# Altium schematic sheet Kobe.SchDoc
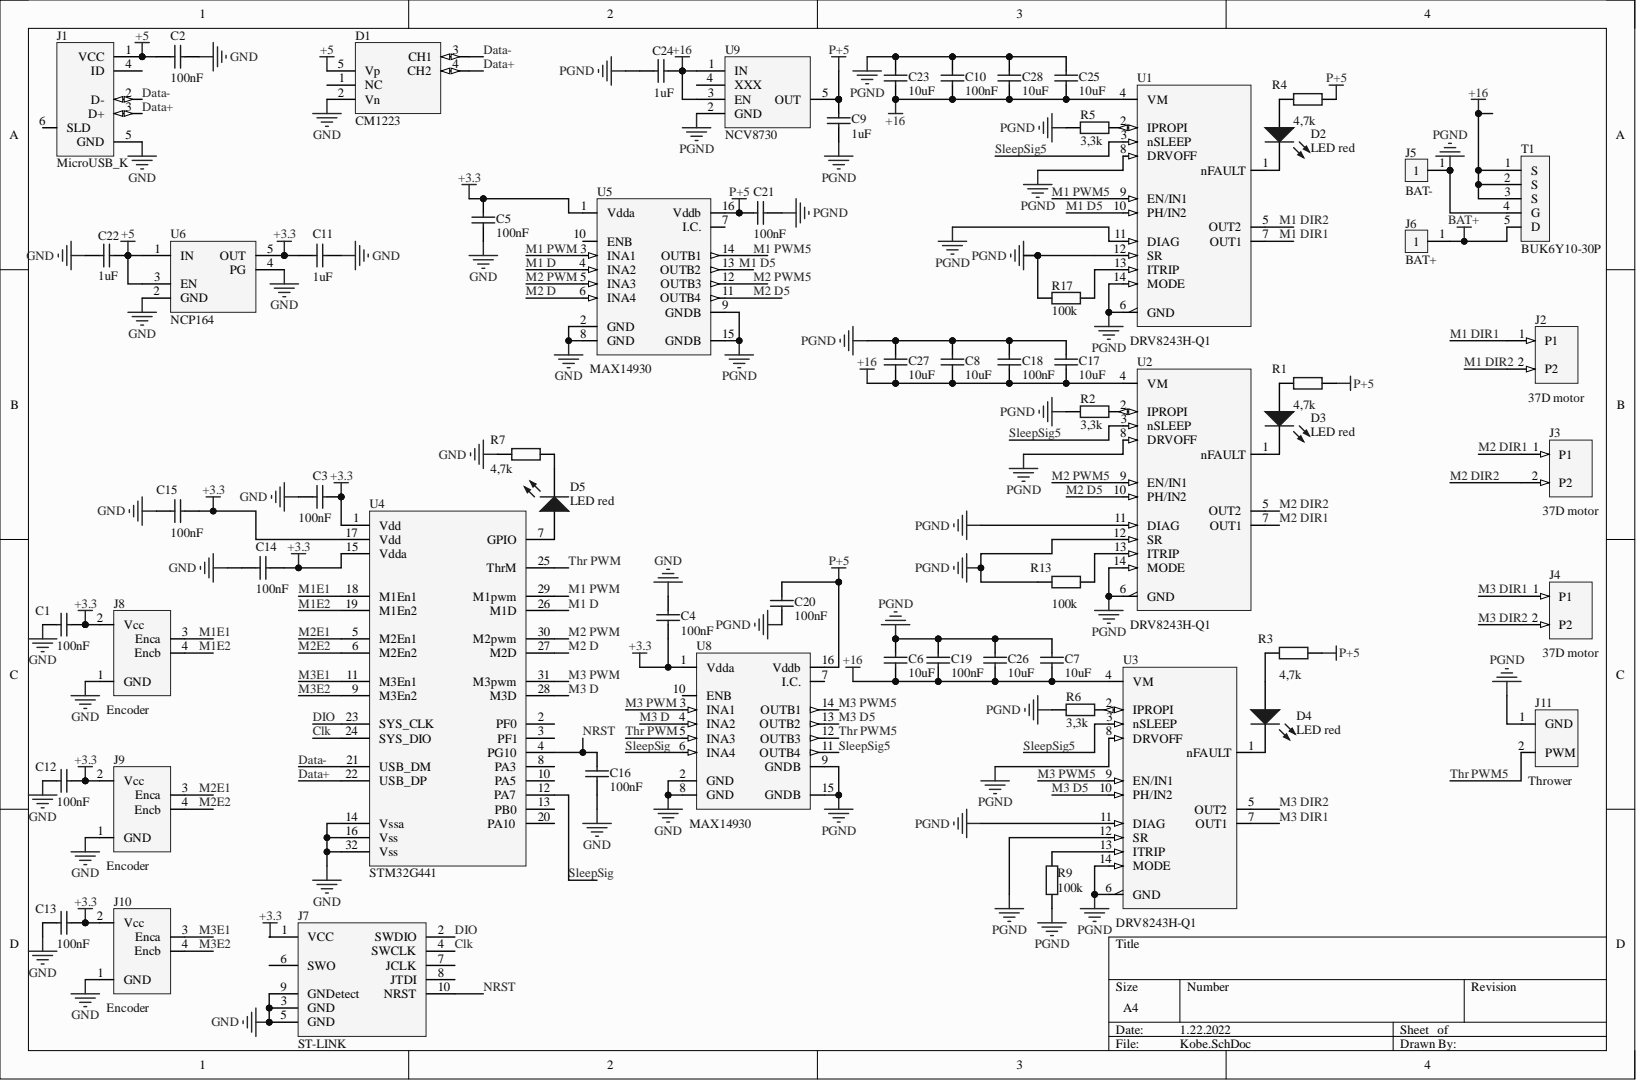
[diagram p0: Kobe.SchDoc]

## Parts
C1  100nF  [Capacitor 0603-Footprint-1]
C2  100nF  [Capacitor 0603-Footprint-1]
C3  100nF  [Capacitor 0603-Footprint-1]
C4  100nF  [Capacitor 0603-Footprint-1]
C5  100nF  [Capacitor 0603-Footprint-1]
C6  10uF  [Cap 1206-Footprint-1]
C7  10uF  [Cap 1206-Footprint-1]
C8  10uF  [Cap 1206-Footprint-1]
C9  1uF  [Capacitor 0603-Footprint-1]
C10  100nF  [Capacitor 0603-Footprint-1]
C11  1uF  [Capacitor 0603-Footprint-1]
C12  100nF  [Capacitor 0603-Footprint-1]
C13  100nF  [Capacitor 0603-Footprint-1]
C14  100nF  [Capacitor 0603-Footprint-1]
C15  100nF  [Capacitor 0603-Footprint-1]
C16  100nF  [Capacitor 0603-Footprint-1]
C17  10uF  [Cap 1206-Footprint-1]
C18  100nF  [Capacitor 0603-Footprint-1]
C19  100nF  [Capacitor 0603-Footprint-1]
C20  100nF  [Capacitor 0603-Footprint-1]
C21  100nF  [Capacitor 0603-Footprint-1]
C22  1uF  [Capacitor 0603-Footprint-1]
C23  10uF  [Cap 1206-Footprint-1]
C24  1uF  [Capacitor 0603-Footprint-1]
C25  10uF  [Cap 1206-Footprint-1]
C26  10uF  [Cap 1206-Footprint-1]
C27  10uF  [Cap 1206-Footprint-1]
C28  10uF  [Cap 1206-Footprint-1]
D1  CM1223  [CM1223-Footprint-1]
D2  LED red  [LED red-Footprint-1]
D3  LED red  [LED red-Footprint-1]
D4  LED red  [LED red-Footprint-1]
D5  LED red  [LED red-Footprint-1]
J1  MicroUSB_K  [MicroUSB Kobe-Footprint-1]
J2  37D motor  [37D motor-Footprint-1]
J3  37D motor  [37D motor-Footprint-1]
J4  37D motor  [37D motor-Footprint-1]
J5  BAT-  [BAT--Footprint-1]
J6  BAT+  [BAT+-Footprint-1]
J7  ST-LINK  [ST-LINK-Footprint-1]
J8  Encoder  [Encoder-Footprint-1]
J9  Encoder  [Encoder-Footprint-1]
J10  Encoder  [Encoder-Footprint-1]
J11  Thrower  [Thrower-Footprint-1]
R1  4,7k  [Res 0603-Footprint-1]
R2  3,3k  [Res 0603-Footprint-1]
R3  4,7k  [Res 0603-Footprint-1]
R4  4,7k  [Res 0603-Footprint-1]
R5  3,3k  [Res 0603-Footprint-1]
R6  3,3k  [Res 0603-Footprint-1]
R7  4,7k  [Res 0603-Footprint-1]
R9  100k  [Res 0603-Footprint-1]
R13  100k  [Res 0603-Footprint-1]
R17  100k  [Res 0603-Footprint-1]
T1  BUK6Y10-30P  [BUK6Y10-30P-Footprint-1]
U1  DRV8243H-Q1  [New footprint 1]
U2  DRV8243H-Q1  [New footprint 1]
U3  DRV8243H-Q1  [New footprint 1]
U4  STM32G441  [STM32G441-Footprint-1]
U5  MAX14930  [PCB-84rmxw6pcxfeioopl5s3-1]
U6  NCP164  [NCP164-Footprint-1]
U8  MAX14930  [PCB-84rmxw6pcxfeioopl5s3-1]
U9  NCV8730  [NCV8730-Footprint-1]

## Nets
+3.3: C1.2 C3.2 C4.1 C5.2 C11.1 C12.2 C13.2 C14.2 C15.2 J7.1(VCC) J8.2(Vcc) J9.2(Vcc) J10.2(Vcc) U4.1(Vdd) U4.15(Vdda) U4.17(Vdd) U5.1(Vdda) U6.5(OUT) U8.1(Vdda)
+5: C2.1 C22.1 D1.5(Vp) J1.1(VCC) U6.1(IN) U6.3(EN)
+16: C6.1 C7.1 C8.1 C10.2 C17.1 C18.2 C19.2 C23.1 C24.1 C25.1 C26.1 C27.1 C28.1 T1.1(S) T1.2(S) T1.3(S) U1.4(VM) U2.4(VM) U3.4(VM) U9.1(IN) U9.3(EN)
BAT+: J6.1 T1.5(D)
GND: C1.1 C2.2 C3.1 C4.2 C5.1 C11.2 C12.1 C13.1 C14.1 C15.1 C16.2 C22.2 D1.2(Vn) J1.5(GND) J7.3(GND) J7.5(GND) J7.9(GNDetect) J8.1(GND) J9.1(GND) J10.1(GND) R7.1 U4.14(Vssa) U4.16(Vss) U4.32(Vss) U5.2(GND) U5.8(GND) U6.2(GND) U6.4(PG) U8.2(GND) U8.8(GND)
P+5: C9.1 C20.2 C21.1 R1.2 R3.2 R4.2 U5.16(Vddb) U8.16(Vddb) U9.5(OUT)
PGND: C6.2 C7.2 C8.2 C9.2 C10.1 C17.2 C18.1 C19.1 C20.1 C21.2 C23.2 C24.2 C25.2 C26.2 C27.2 C28.2 J5.1 J11.1 R2.2 R5.2 R6.2 R9.1 R13.1 R17.2 T1.4(G) U1.6(GND) U1.8(DRVOFF) U1.11(DIAG) U1.12(SR) U1.14(MODE) U2.6(GND) U2.8(DRVOFF) U2.11(DIAG) U2.12(SR) U2.14(MODE) U3.6(GND) U3.8(DRVOFF) U3.11(DIAG) U3.12(SR) U3.14(MODE) U5.9(GNDB) U5.15(GNDB) U8.9(GNDB) U8.15(GNDB) U9.2(GND)
Clk: J7.4(SWCLK) U4.24(SYS_DIO)
Data+: D1.4(CH2) J1.3(D+) U4.22(USB_DP)
Data-: D1.3(CH1) J1.2(D-) U4.21(USB_DM)
DIO: J7.2(SWDIO) U4.23(SYS_CLK)
M1 D: U4.26(M1D) U5.4(INA2)
M1 D5: U1.10(PH/IN2) U5.13(OUTB2)
M1 DIR1: J2.1 U1.7(OUT1)
M1 DIR2: J2.2 U1.5(OUT2)
M1 PWM: U4.29(M1pwm) U5.3(INA1)
M1 PWM5: U1.9(EN/IN1) U5.14(OUTB1)
M1E1: J8.3(Enca) U4.18(M1En1)
M1E2: J8.4(Encb) U4.19(M1En2)
M2 D: U4.27(M2D) U5.6(INA4)
M2 D5: U2.10(PH/IN2) U5.11(OUTB4)
M2 DIR1: J3.1 U2.7(OUT1)
M2 DIR2: J3.2 U2.5(OUT2)
M2 PWM: U4.30(M2pwm) U5.5(INA3)
M2 PWM5: U2.9(EN/IN1) U5.12(OUTB3)
M2E1: J9.3(Enca) U4.5(M2En1)
M2E2: J9.4(Encb) U4.6(M2En2)
M3 D: U4.28(M3D) U8.4(INA2)
M3 D5: U3.10(PH/IN2) U8.13(OUTB2)
M3 DIR1: J4.1 U3.7(OUT1)
M3 DIR2: J4.2 U3.5(OUT2)
M3 PWM: U4.31(M3pwm) U8.3(INA1)
M3 PWM5: U3.9(EN/IN1) U8.14(OUTB1)
M3E1: J10.3(Enca) U4.11(M3En1)
M3E2: J10.4(Encb) U4.9(M3En2)
NRST: C16.1 J7.10(NRST) U4.4(PG10)
SleepSig: U4.12(PA7) U8.6(INA4)
SleepSig5: U1.3(nSLEEP) U2.3(nSLEEP) U3.3(nSLEEP) U8.11(OUTB4)
Thr PWM: U4.25(ThrM) U8.5(INA3)
Thr PWM5: J11.2 U8.12(OUTB3)
NetD2_1: D2.1 R4.1
NetD2_2: D2.2 U1.1(nFAULT)
NetD3_1: D3.1 R1.1
NetD3_2: D3.2 U2.1(nFAULT)
NetD4_1: D4.1 R3.1
NetD4_2: D4.2 U3.1(nFAULT)
NetD5_1: D5.1 U4.7(GPIO)
NetD5_2: D5.2 R7.2
NetR2_1: R2.1 U2.2(IPROPI)
NetR5_1: R5.1 U1.2(IPROPI)
NetR6_1: R6.1 U3.2(IPROPI)
NetR9_2: R9.2 U3.13(ITRIP)
NetR13_2: R13.2 U2.13(ITRIP)
NetR17_1: R17.1 U1.13(ITRIP)

Not connected: D1 1(NC); J1 4(ID) 6(SLD); J7 6(SWO) 7(JCLK) 8(JTDI); U4 2(PF0) 3(PF1) 8(PA3) 10(PA5) 13(PB0) 20(PA10); U5 7(I.C.) 10(ENB); U8 7(I.C.) 10(ENB); U9 4(XXX)

## Library data of the parts
C1 = CMP-g4figae3br6edw50sj97-2: Kondekas toite jaoks; ReplaceComponentId: QXJNIHPQ; Component Kind: Standard; CustomComponentID: g4figae3br6edw50sj97; Library Name: My Personal Space; PartId: 1
C2 = CMP-g4figae3br6edw50sj97-2: Kondekas toite jaoks; ReplaceComponentId: LWVMDZGM; Component Kind: Standard; CustomComponentID: g4figae3br6edw50sj97; Library Name: My Personal Space; PartId: 1
C3 = CMP-g4figae3br6edw50sj97-2: Kondekas toite jaoks; ReplaceComponentId: HDSDZMXK; Component Kind: Standard; CustomComponentID: g4figae3br6edw50sj97; Library Name: My Personal Space; PartId: 1
C4 = CMP-g4figae3br6edw50sj97-2: Kondekas toite jaoks; ReplaceComponentId: BXIVBJPT; Component Kind: Standard; CustomComponentID: g4figae3br6edw50sj97; Library Name: My Personal Space; PartId: 1
C5 = CMP-g4figae3br6edw50sj97-2: Kondekas toite jaoks; ReplaceComponentId: SYWEGAUD; Component Kind: Standard; CustomComponentID: g4figae3br6edw50sj97; Library Name: My Personal Space; PartId: 1
C6-C8 C17 C23 C25-C28 = CMP-nz8wtry6sz9ghr0bdznt-1: Capacitor 1206; Component Kind: Standard; CustomComponentID: nz8wtry6sz9ghr0bdznt; Library Name: My Personal Space
C9 = CMP-g4figae3br6edw50sj97-2: Kondekas toite jaoks; ReplaceComponentId: UPAHNOOW; Component Kind: Standard; CustomComponentID: g4figae3br6edw50sj97; Library Name: My Personal Space; PartId: 1
C10 C18 C19 = CMP-g4figae3br6edw50sj97-2: Kondekas toite jaoks; ReplaceComponentId: RNKTVTKK; Component Kind: Standard; CustomComponentID: g4figae3br6edw50sj97; Library Name: My Personal Space; PartId: 1
C11 C22 = CMP-g4figae3br6edw50sj97-2: Kondekas toite jaoks; ReplaceComponentId: WRXFJEIN; Component Kind: Standard; CustomComponentID: g4figae3br6edw50sj97; Library Name: My Personal Space; PartId: 1
C12 = CMP-g4figae3br6edw50sj97-2: Kondekas toite jaoks; ReplaceComponentId: ARRJWFSM; Component Kind: Standard; CustomComponentID: g4figae3br6edw50sj97; Library Name: My Personal Space; PartId: 1
C13 = CMP-g4figae3br6edw50sj97-2: Kondekas toite jaoks; ReplaceComponentId: YRGIVPHM; Component Kind: Standard; CustomComponentID: g4figae3br6edw50sj97; Library Name: My Personal Space; PartId: 1
C14 = CMP-g4figae3br6edw50sj97-2: Kondekas toite jaoks; ReplaceComponentId: YVIIQBOT; Component Kind: Standard; CustomComponentID: g4figae3br6edw50sj97; Library Name: My Personal Space; PartId: 1
C15 = CMP-g4figae3br6edw50sj97-2: Kondekas toite jaoks; ReplaceComponentId: WGZMWOWH; Component Kind: Standard; CustomComponentID: g4figae3br6edw50sj97; Library Name: My Personal Space; PartId: 1
C16 = CMP-g4figae3br6edw50sj97-2: Kondekas toite jaoks; ReplaceComponentId: PQVYLEFT; Component Kind: Standard; CustomComponentID: g4figae3br6edw50sj97; Library Name: My Personal Space; PartId: 1
C20 = CMP-g4figae3br6edw50sj97-2: Kondekas toite jaoks; ReplaceComponentId: VKLBJWWP; Component Kind: Standard; CustomComponentID: g4figae3br6edw50sj97; Library Name: My Personal Space; PartId: 1
C21 = CMP-g4figae3br6edw50sj97-2: Kondekas toite jaoks; ReplaceComponentId: SXEWBLQL; Component Kind: Standard; CustomComponentID: g4figae3br6edw50sj97; Library Name: My Personal Space; PartId: 1
C24 = CMP-g4figae3br6edw50sj97-2: Kondekas toite jaoks; ReplaceComponentId: OFTCDOFN; Component Kind: Standard; CustomComponentID: g4figae3br6edw50sj97; Library Name: My Personal Space; PartId: 1
D1 = CMP-2zhtxkk7tc9sql6uishn-7: TVS diode, USB; ReplaceComponentId: UULFGQCP; Component Kind: Standard; CustomComponentID: 2zhtxkk7tc9sql6uishn; Library Name: My Personal Space; PartId: 1
D2 = CMP-r4czjv7pygs5mjbj75ts-2: Punane led. Driveri nFaault. 2V pingelandg; ReplaceComponentId: YTTIPKNN; Component Kind: Standard; CustomComponentID: r4czjv7pygs5mjbj75ts; Library Name: My Personal Space; PartId: 1
D3 = CMP-r4czjv7pygs5mjbj75ts-2: Punane led. Driveri nFaault. 2V pingelandg; ReplaceComponentId: JXVFREHO; Component Kind: Standard; CustomComponentID: r4czjv7pygs5mjbj75ts; Library Name: My Personal Space; PartId: 1
D4 = CMP-r4czjv7pygs5mjbj75ts-2: Punane led. Driveri nFaault. 2V pingelandg; ReplaceComponentId: SKKNGBPD; Component Kind: Standard; CustomComponentID: r4czjv7pygs5mjbj75ts; Library Name: My Personal Space; PartId: 1
D5 = CMP-r4czjv7pygs5mjbj75ts-2: Punane led. Driveri nFaault. 2V pingelandg; ReplaceComponentId: CLEKRMGZ; Component Kind: Standard; CustomComponentID: r4czjv7pygs5mjbj75ts; Library Name: My Personal Space; PartId: 1
J1 = CMP-4mjwl77p7r3ec30o5xxv-6: Kobe usbi pesa; ReplaceComponentId: XAPRRCQO; Component Kind: Standard; CustomComponentID: 4mjwl77p7r3ec30o5xxv; Library Name: My Personal Space; PartId: 1
J2 = CMP-i2bzeejsfb5u42lo9rns-10: Wheel motor; ReplaceComponentId: SMMJKNBB; Component Kind: Standard; CustomComponentID: i2bzeejsfb5u42lo9rns; Library Name: My Personal Space; PartId: 1
J3 = CMP-i2bzeejsfb5u42lo9rns-10: Wheel motor; ReplaceComponentId: ZCVXBZTX; Component Kind: Standard; CustomComponentID: i2bzeejsfb5u42lo9rns; Library Name: My Personal Space; PartId: 1
J4 = CMP-i2bzeejsfb5u42lo9rns-10: Wheel motor; ReplaceComponentId: ISJOZCJF; Component Kind: Standard; CustomComponentID: i2bzeejsfb5u42lo9rns; Library Name: My Personal Space; PartId: 1
J5 = CMP-lnoemnqs88nfpmo161ng-5: Aku - klemm; ReplaceComponentId: CEIJDCLF; Component Kind: Standard; CustomComponentID: lnoemnqs88nfpmo161ng; Library Name: My Personal Space; PartId: 1
J6 = CMP-zdjo55cgs87mrb3dxpqg-5: Aku + klemm; ReplaceComponentId: CUKNRDOL; Component Kind: Standard; CustomComponentID: zdjo55cgs87mrb3dxpqg; Library Name: My Personal Space; PartId: 1
J7 = CMP-irpj9flsqxxph5s22trj-5: Programmer for microcontroller; ReplaceComponentId: JOMNCEKH; Component Kind: Standard; CustomComponentID: irpj9flsqxxph5s22trj; Library Name: My Personal Space; PartId: 1
J8 = CMP-lt5wprht009qxskx8lxi-7: Encoder for motor; ReplaceComponentId: BCCLRMOX; Component Kind: Standard; CustomComponentID: lt5wprht009qxskx8lxi; Library Name: My Personal Space; PartId: 1
J9 = CMP-lt5wprht009qxskx8lxi-7: Encoder for motor; ReplaceComponentId: PUHPMMXN; Component Kind: Standard; CustomComponentID: lt5wprht009qxskx8lxi; Library Name: My Personal Space; PartId: 1
J10 = CMP-lt5wprht009qxskx8lxi-7: Encoder for motor; ReplaceComponentId: PYXTSLMA; Component Kind: Standard; CustomComponentID: lt5wprht009qxskx8lxi; Library Name: My Personal Space; PartId: 1
J11 = CMP-kch6k4nh9v9zy50hbv0b-3: Thrower motor; ReplaceComponentId: VIERDUBE; Component Kind: Standard; CustomComponentID: kch6k4nh9v9zy50hbv0b; Library Name: My Personal Space; PartId: 1
R1 = CMP-lh0bsdmri87enyt4h89l-2: Takisti.,; ReplaceComponentId: AJLUWIHE; Component Kind: Standard; CustomComponentID: lh0bsdmri87enyt4h89l; Library Name: My Personal Space; PartId: 1
R2 = CMP-lh0bsdmri87enyt4h89l-2: Takisti.,; ReplaceComponentId: UFDQDJDL; Component Kind: Standard; CustomComponentID: lh0bsdmri87enyt4h89l; Library Name: My Personal Space; PartId: 1
R3 = CMP-lh0bsdmri87enyt4h89l-2: Takisti.,; ReplaceComponentId: JWCQNPOK; Component Kind: Standard; CustomComponentID: lh0bsdmri87enyt4h89l; Library Name: My Personal Space; PartId: 1
R4 = CMP-lh0bsdmri87enyt4h89l-2: Takisti.,; ReplaceComponentId: FSRJCDWH; Component Kind: Standard; CustomComponentID: lh0bsdmri87enyt4h89l; Library Name: My Personal Space; PartId: 1
R5 = CMP-lh0bsdmri87enyt4h89l-2: Takisti.,; ReplaceComponentId: HTEKWQSP; Component Kind: Standard; CustomComponentID: lh0bsdmri87enyt4h89l; Library Name: My Personal Space; PartId: 1
R6 = CMP-lh0bsdmri87enyt4h89l-2: Takisti.,; ReplaceComponentId: ZFWFUMWR; Component Kind: Standard; CustomComponentID: lh0bsdmri87enyt4h89l; Library Name: My Personal Space; PartId: 1
R7 = CMP-lh0bsdmri87enyt4h89l-2: Takisti.,; ReplaceComponentId: CPFFTSEY; Component Kind: Standard; CustomComponentID: lh0bsdmri87enyt4h89l; Library Name: My Personal Space; PartId: 1
R9 = CMP-lh0bsdmri87enyt4h89l-2: Takisti.,; ReplaceComponentId: KWUTMENY; Component Kind: Standard; CustomComponentID: lh0bsdmri87enyt4h89l; Library Name: My Personal Space; PartId: 1
R13 = CMP-lh0bsdmri87enyt4h89l-2: Takisti.,; ReplaceComponentId: YQNTUYOL; Component Kind: Standard; CustomComponentID: lh0bsdmri87enyt4h89l; Library Name: My Personal Space; PartId: 1
R17 = CMP-lh0bsdmri87enyt4h89l-2: Takisti.,; ReplaceComponentId: BNTXZERW; Component Kind: Standard; CustomComponentID: lh0bsdmri87enyt4h89l; Library Name: My Personal Space; PartId: 1
T1 = CMP-gaeiu5tj53k246w242nk-3: Reverse voltage protection; ReplaceComponentId: UMWGHNZH; Component Kind: Standard; CustomComponentID: gaeiu5tj53k246w242nk; Library Name: My Personal Space; PartId: 1
U1 = CMP-fgvuxalh7zcr11ntz7qg-12: Motor driver; ReplaceComponentId: FBSBMXAM; Component Kind: Standard; CustomComponentID: fgvuxalh7zcr11ntz7qg; Library Name: My Personal Space; PartId: 1
U2 = CMP-fgvuxalh7zcr11ntz7qg-12: Motor driver; ReplaceComponentId: WTZFGIXG; Component Kind: Standard; CustomComponentID: fgvuxalh7zcr11ntz7qg; Library Name: My Personal Space; PartId: 1
U3 = CMP-fgvuxalh7zcr11ntz7qg-12: Motor driver; ReplaceComponentId: LIAJAXMW; Component Kind: Standard; CustomComponentID: fgvuxalh7zcr11ntz7qg; Library Name: My Personal Space; PartId: 1
U4 = CMP-bpabx5unyhsxol5aqmzk-3: Microcontroller; ReplaceComponentId: QMGYHSDV; Component Kind: Standard; CustomComponentID: bpabx5unyhsxol5aqmzk; Library Name: My Personal Space; PartId: 1
U5 = CMP-2zesrm78vvyd06gt9qdn-4: 4 channel isolator; ReplaceComponentId: XCIDGRRG; Component Kind: Standard; CustomComponentID: 2zesrm78vvyd06gt9qdn; Library Name: My Personal Space; PartId: 1
U6 = CMP-m988v1ffh4gxxh5ujj6g-3: TSOP-5 microcontroller voltage regulator; ReplaceComponentId: BYGNKKDC; Component Kind: Standard; CustomComponentID: m988v1ffh4gxxh5ujj6g; Library Name: My Personal Space; PartId: 1
U8 = CMP-2zesrm78vvyd06gt9qdn-4: 4 channel isolator; ReplaceComponentId: KBBPDSCM; Component Kind: Standard; CustomComponentID: 2zesrm78vvyd06gt9qdn; Library Name: My Personal Space; PartId: 1
U9 = CMP-b4q9s3icilr42xwmqc35-3: TSOP-5 Voltage regulator motor driver; ReplaceComponentId: SOYHTSCK; Component Kind: Standard; CustomComponentID: b4q9s3icilr42xwmqc35; Library Name: My Personal Space; PartId: 1
